# Altium schematic sheet MCU.SchDoc(MCU)
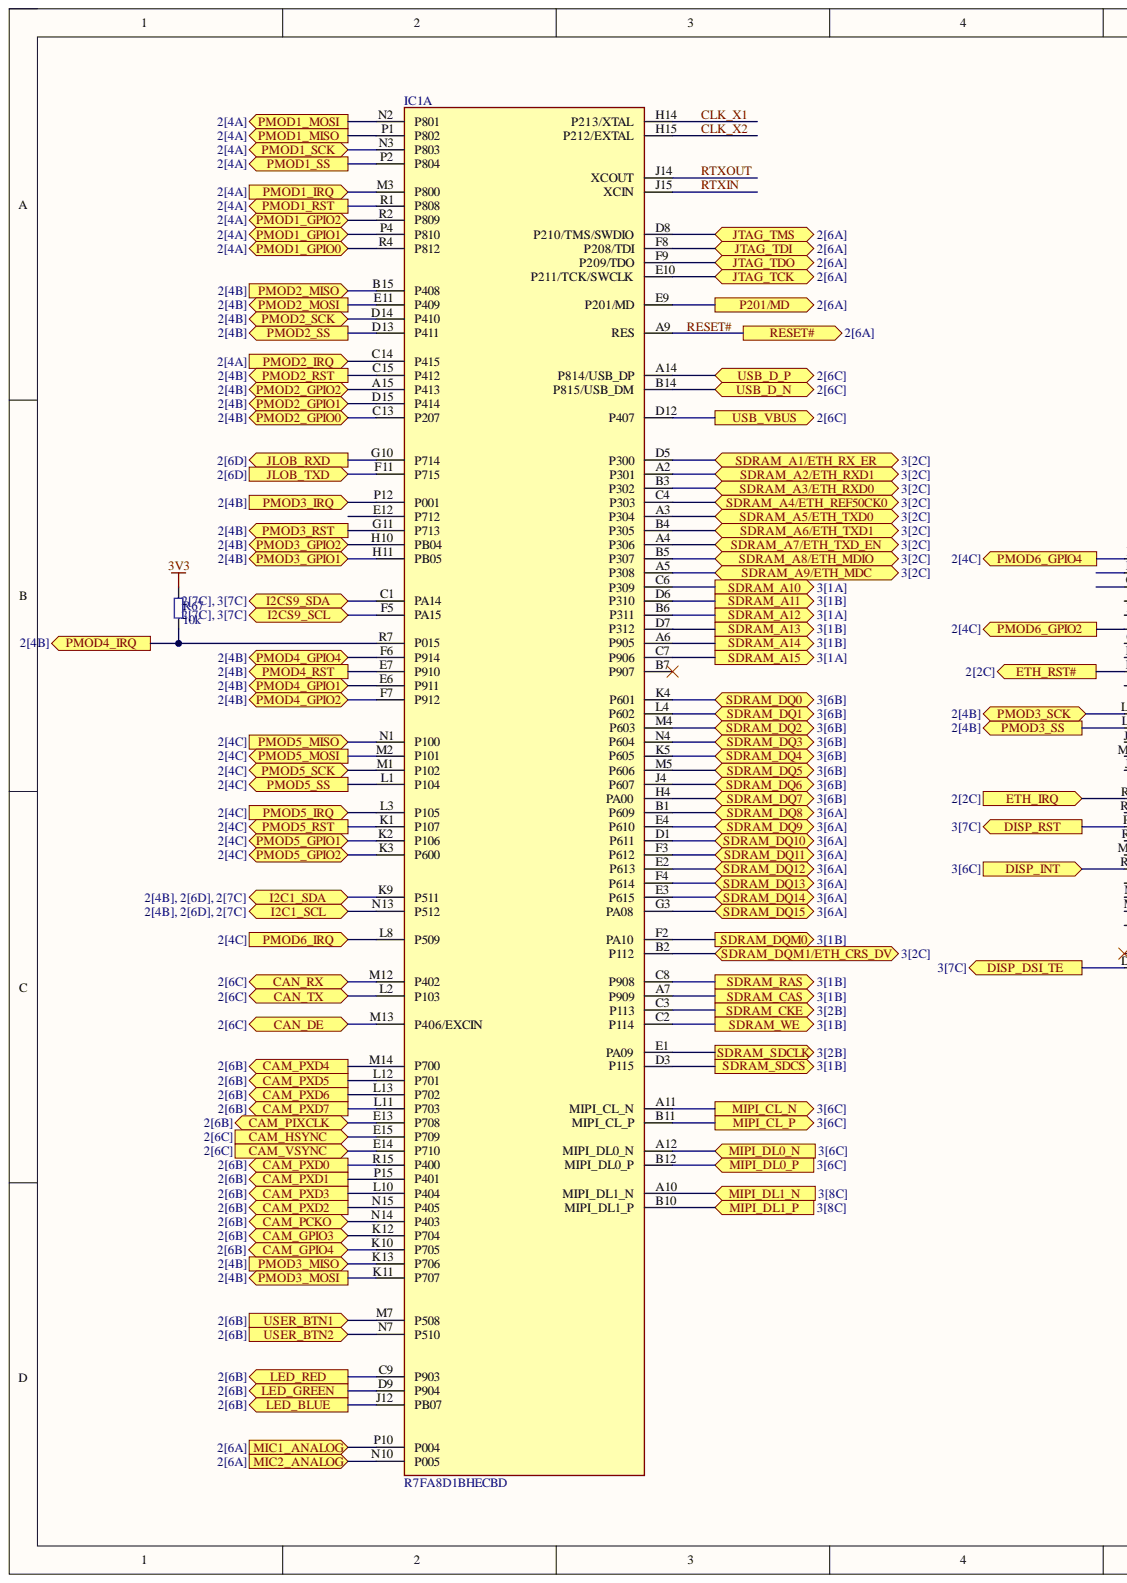
[diagram p0: MCU.SchDoc, left]
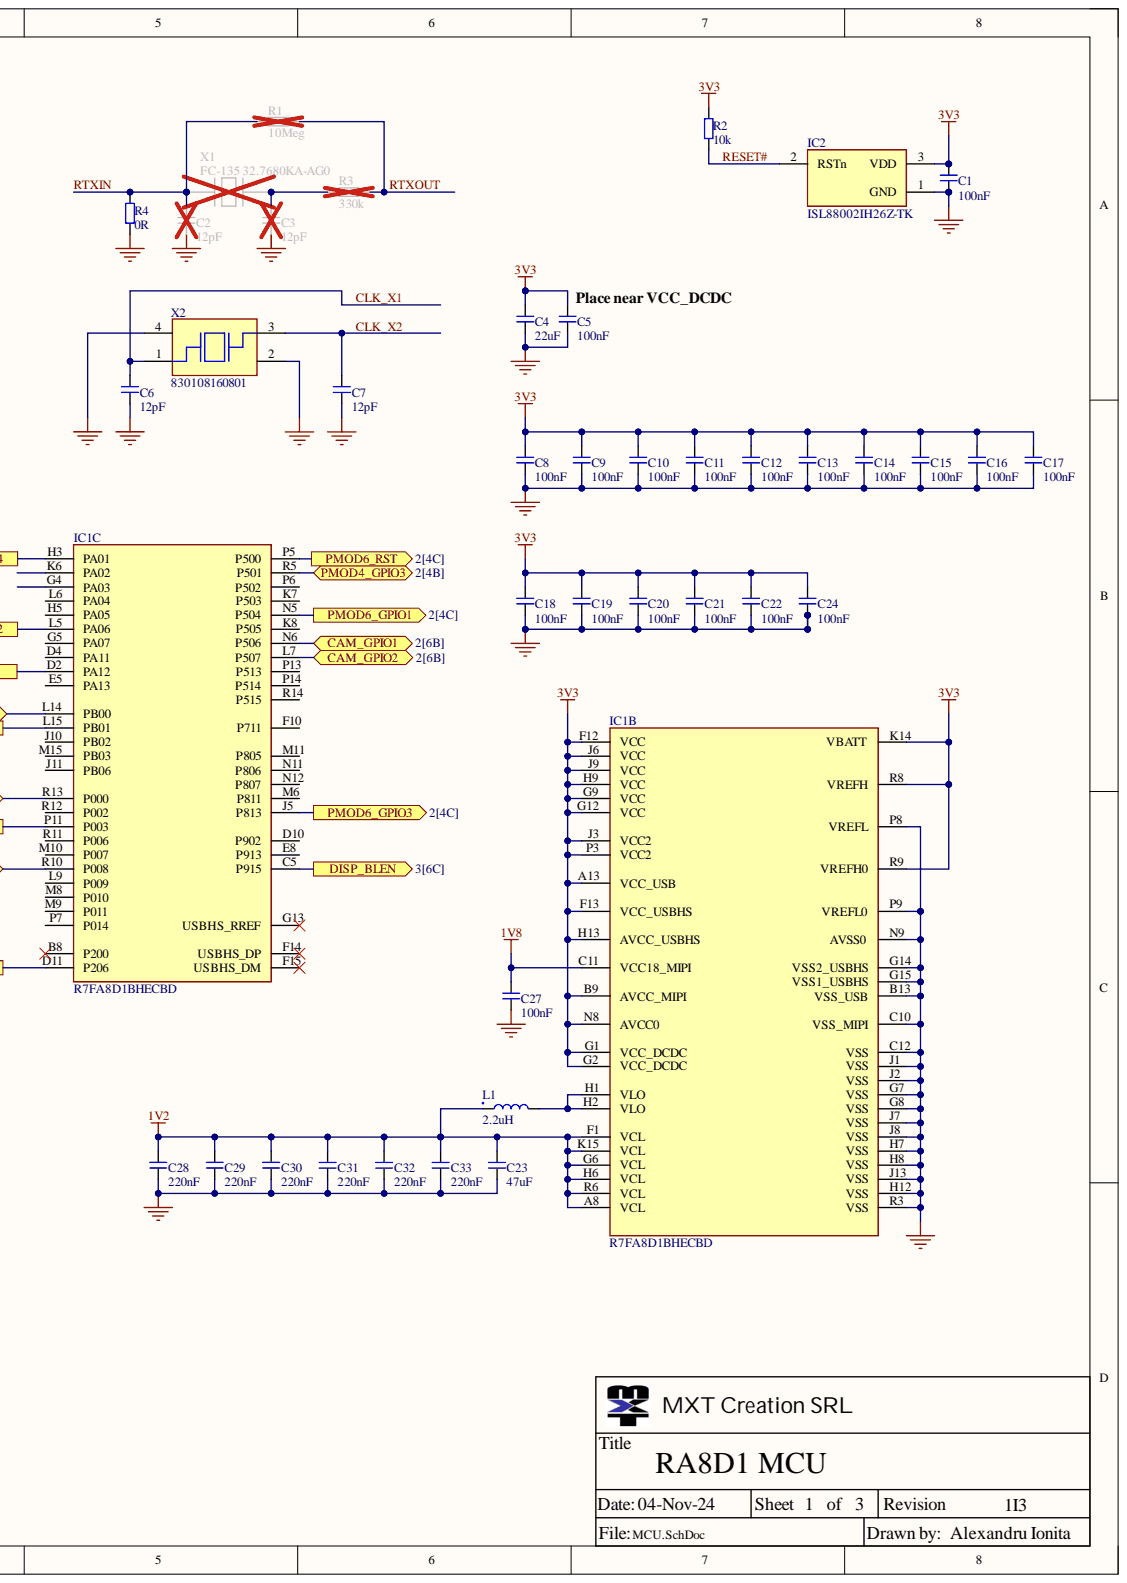
[diagram p1: MCU.SchDoc, right]

## Parts
C1  100nF  [CAP0402]
C2  12pF  [CAP0402]
C3  12pF  [CAP0402]
C4  22uF  [CAP0402]
C5  100nF  [CAP0402]
C6  12pF  [CAP0402]
C7  12pF  [CAP0402]
C8  100nF  [CAP0402]
C9  100nF  [CAP0402]
C10  100nF  [CAP0402]
C11  100nF  [CAP0402]
C12  100nF  [CAP0402]
C13  100nF  [CAP0402]
C14  100nF  [CAP0402]
C15  100nF  [CAP0402]
C16  100nF  [CAP0402]
C17  100nF  [CAP0402]
C18  100nF  [CAP0402]
C19  100nF  [CAP0402]
C20  100nF  [CAP0402]
C21  100nF  [CAP0402]
C22  100nF  [CAP0402]
C23  47uF  [CAP0603]
C24  100nF  [CAP0402]
C27  100nF  [CAP0402]
C28  220nF  [CAP0402]
C29  220nF  [CAP0402]
C30  220nF  [CAP0402]
C31  220nF  [CAP0402]
C32  220nF  [CAP0402]
C33  220nF  [CAP0402]
IC1  R7FA8D1BHECBD  [224-LFBGA-RENESAS]  (parts A,B,C)
IC2  ISL88002IH26Z-TK  [SOT-23-3-RENESAS]
L1  2.2uH  [INDUCTOR0805]
R1  10Meg  [RES0402]
R2  10k  [RES0402]
R3  330k  [RES0402]
R4  0R  [RES0402]
R67  10k  [RES0402]
X1  FC-135 32.7680KA-AG0  [3.2x1.5-EPSON]
X2  830108160801  [X1612]

## Ports
CAM_GPIO1, CAM_GPIO2, CAM_GPIO3, CAM_GPIO4, CAM_HSYNC, CAM_PCKO, CAM_PIXCLK, CAM_PXD0, CAM_PXD1, CAM_PXD2, CAM_PXD3, CAM_PXD4, CAM_PXD5, CAM_PXD6, CAM_PXD7, CAM_VSYNC, CAN_DE, CAN_RX, CAN_TX, DISP_BLEN, DISP_DSI_TE, DISP_INT, DISP_RST, ETH_IRQ, ETH_RST#, I2C1_SCL, I2C1_SDA, I2CS9_SCL, I2CS9_SDA, JLOB_RXD, JLOB_TXD, JTAG_TCK, JTAG_TDI, JTAG_TDO, JTAG_TMS, LED_BLUE, LED_GREEN, LED_RED, MIC1_ANALOG, MIC2_ANALOG, MIPI_CL_N, MIPI_CL_P, MIPI_DL0_N, MIPI_DL0_P, MIPI_DL1_N, MIPI_DL1_P, P201/MD, PMOD1_GPIO0, PMOD1_GPIO1, PMOD1_GPIO2, PMOD1_IRQ, PMOD1_MISO, PMOD1_MOSI, PMOD1_RST, PMOD1_SCK, PMOD1_SS, PMOD2_GPIO0, PMOD2_GPIO1, PMOD2_GPIO2, PMOD2_IRQ, PMOD2_MISO, PMOD2_MOSI, PMOD2_RST, PMOD2_SCK, PMOD2_SS, PMOD3_GPIO1, PMOD3_GPIO2, PMOD3_IRQ, PMOD3_MISO, PMOD3_MOSI, PMOD3_RST, PMOD3_SCK, PMOD3_SS, PMOD4_GPIO1, PMOD4_GPIO2, PMOD4_GPIO3, PMOD4_GPIO4, PMOD4_IRQ, PMOD4_RST, PMOD5_GPIO1, PMOD5_GPIO2, PMOD5_IRQ, PMOD5_MISO, PMOD5_MOSI, PMOD5_RST, PMOD5_SCK, PMOD5_SS, PMOD6_GPIO1, PMOD6_GPIO2, PMOD6_GPIO3, PMOD6_GPIO4, PMOD6_IRQ, PMOD6_RST, RESET#, SDRAM_A1/ETH_RX_ER, SDRAM_A2/ETH_RXD1, SDRAM_A3/ETH_RXD0, SDRAM_A4/ETH_REF50CK0, SDRAM_A5/ETH_TXD0, SDRAM_A6/ETH_TXD1, SDRAM_A7/ETH_TXD_EN, SDRAM_A8/ETH_MDIO, SDRAM_A9/ETH_MDC, SDRAM_A10, SDRAM_A11, SDRAM_A12, SDRAM_A13, SDRAM_A14, SDRAM_A15, SDRAM_CAS, SDRAM_CKE, SDRAM_DQ0, SDRAM_DQ1, SDRAM_DQ2, SDRAM_DQ3, SDRAM_DQ4, SDRAM_DQ5, SDRAM_DQ6, SDRAM_DQ7, SDRAM_DQ8, SDRAM_DQ9, SDRAM_DQ10, SDRAM_DQ11, SDRAM_DQ12, SDRAM_DQ13, SDRAM_DQ14, SDRAM_DQ15, SDRAM_DQM0, SDRAM_DQM1/ETH_CRS_DV, SDRAM_RAS, SDRAM_SDCLK, SDRAM_SDCS, SDRAM_WE, USB_D_N, USB_D_P, USB_VBUS, USER_BTN1, USER_BTN2

## Nets
1V2: C23.2 C28.2 C29.2 C30.2 C31.2 C32.2 C33.2 IC1.A8(VCL) IC1.F1(VCL) IC1.G6(VCL) IC1.H6(VCL) IC1.K15(VCL) IC1.R6(VCL) L1.1
1V8: C27.2 IC1.C11(VCC18_MIPI)
3V3: C1.2 C4.2 C5.2 C8.2 C9.2 C10.2 C11.2 C12.2 C13.2 C14.2 C15.2 C16.2 C17.2 C18.2 C19.2 C20.2 C21.2 C22.2 C24.2 IC1.A13(VCC_USB) IC1.B9(AVCC_MIPI) IC1.F12(VCC) IC1.F13(VCC_USBHS) IC1.G1(VCC_DCDC) IC1.G2(VCC_DCDC) IC1.G9(VCC) IC1.G12(VCC) IC1.H9(VCC) IC1.H13(AVCC_USBHS) IC1.J3(VCC2) IC1.J6(VCC) IC1.J9(VCC) IC1.K14(VBATT) IC1.N8(AVCC0) IC1.P3(VCC2) IC1.R8(VREFH) IC1.R9(VREFH0) IC2.3 R2.2 R67.2
GND: C1.1 C2.1 C3.1 C4.1 C5.1 C6.1 C7.1 C8.1 C9.1 C10.1 C11.1 C12.1 C13.1 C14.1 C15.1 C16.1 C17.1 C18.1 C19.1 C20.1 C21.1 C22.1 C23.1 C24.1 C27.1 C28.1 C29.1 C30.1 C31.1 C32.1 C33.1 IC1.B13(VSS_USB) IC1.C10(VSS_MIPI) IC1.C12(VSS) IC1.G7(VSS) IC1.G8(VSS) IC1.G14(VSS2_USBHS) IC1.G15(VSS1_USBHS) IC1.H7(VSS) IC1.H8(VSS) IC1.H12(VSS) IC1.J1(VSS) IC1.J2(VSS) IC1.J7(VSS) IC1.J8(VSS) IC1.J13(VSS) IC1.N9(AVSS0) IC1.P8(VREFL) IC1.P9(VREFL0) IC1.R3(VSS) IC2.1 R4.1 X2.2 X2.4
CAM_GPIO1: IC1.N6(P506) ; port CAM_GPIO1
CAM_GPIO2: IC1.L7(P507) ; port CAM_GPIO2
CAM_GPIO3: IC1.K12(P704) ; port CAM_GPIO3
CAM_GPIO4: IC1.K10(P705) ; port CAM_GPIO4
CAM_HSYNC: IC1.E15(P709) ; port CAM_HSYNC
CAM_PCKO: IC1.N14(P403) ; port CAM_PCKO
CAM_PIXCLK: IC1.E13(P708) ; port CAM_PIXCLK
CAM_PXD0: IC1.R15(P400) ; port CAM_PXD0
CAM_PXD1: IC1.P15(P401) ; port CAM_PXD1
CAM_PXD2: IC1.N15(P405) ; port CAM_PXD2
CAM_PXD3: IC1.L10(P404) ; port CAM_PXD3
CAM_PXD4: IC1.M14(P700) ; port CAM_PXD4
CAM_PXD5: IC1.L12(P701) ; port CAM_PXD5
CAM_PXD6: IC1.L13(P702) ; port CAM_PXD6
CAM_PXD7: IC1.L11(P703) ; port CAM_PXD7
CAM_VSYNC: IC1.E14(P710) ; port CAM_VSYNC
CAN_DE: IC1.M13(P406/EXCIN) ; port CAN_DE
CAN_RX: IC1.M12(P402) ; port CAN_RX
CAN_TX: IC1.L2(P103) ; port CAN_TX
CLK_X1: C6.2 IC1.H14(P213/XTAL) X2.1
CLK_X2: C7.2 IC1.H15(P212/EXTAL) X2.3
DISP_BLEN: IC1.C5(P915) ; port DISP_BLEN
DISP_DSI_TE: IC1.D11(P206) ; port DISP_DSI_TE
DISP_INT: IC1.R10(P008) ; port DISP_INT
DISP_RST: IC1.P11(P003) ; port DISP_RST
ETH_IRQ: IC1.R13(P000) ; port ETH_IRQ
ETH_RST#: IC1.D2(PA12) ; port ETH_RST#
I2C1_SCL: IC1.N13(P512) ; port I2C1_SCL
I2C1_SDA: IC1.K9(P511) ; port I2C1_SDA
I2CS9_SCL: IC1.F5(PA15) ; port I2CS9_SCL
I2CS9_SDA: IC1.C1(PA14) ; port I2CS9_SDA
JLOB_RXD: IC1.G10(P714) ; port JLOB_RXD
JLOB_TXD: IC1.F11(P715) ; port JLOB_TXD
JTAG_TCK: IC1.E10(P211/TCK/SWCLK) ; port JTAG_TCK
JTAG_TDI: IC1.F8(P208/TDI) ; port JTAG_TDI
JTAG_TDO: IC1.F9(P209/TDO) ; port JTAG_TDO
JTAG_TMS: IC1.D8(P210/TMS/SWDIO) ; port JTAG_TMS
LED_BLUE: IC1.J12(PB07) ; port LED_BLUE
LED_GREEN: IC1.D9(P904) ; port LED_GREEN
LED_RED: IC1.C9(P903) ; port LED_RED
MIC1_ANALOG: IC1.P10(P004) ; port MIC1_ANALOG
MIC2_ANALOG: IC1.N10(P005) ; port MIC2_ANALOG
MIPI_CL_N: IC1.A11(MIPI_CL_N) ; port MIPI_CL_N
MIPI_CL_P: IC1.B11(MIPI_CL_P) ; port MIPI_CL_P
MIPI_DL0_N: IC1.A12(MIPI_DL0_N) ; port MIPI_DL0_N
MIPI_DL0_P: IC1.B12(MIPI_DL0_P) ; port MIPI_DL0_P
MIPI_DL1_N: IC1.A10(MIPI_DL1_N) ; port MIPI_DL1_N
MIPI_DL1_P: IC1.B10(MIPI_DL1_P) ; port MIPI_DL1_P
P201/MD: IC1.E9(P201/MD) ; port P201/MD
PMOD1_GPIO0: IC1.R4(P812) ; port PMOD1_GPIO0
PMOD1_GPIO1: IC1.P4(P810) ; port PMOD1_GPIO1
PMOD1_GPIO2: IC1.R2(P809) ; port PMOD1_GPIO2
PMOD1_IRQ: IC1.M3(P800) ; port PMOD1_IRQ
PMOD1_MISO: IC1.P1(P802) ; port PMOD1_MISO
PMOD1_MOSI: IC1.N2(P801) ; port PMOD1_MOSI
PMOD1_RST: IC1.R1(P808) ; port PMOD1_RST
PMOD1_SCK: IC1.N3(P803) ; port PMOD1_SCK
PMOD1_SS: IC1.P2(P804) ; port PMOD1_SS
PMOD2_GPIO0: IC1.C13(P207) ; port PMOD2_GPIO0
PMOD2_GPIO1: IC1.D15(P414) ; port PMOD2_GPIO1
PMOD2_GPIO2: IC1.A15(P413) ; port PMOD2_GPIO2
PMOD2_IRQ: IC1.C14(P415) ; port PMOD2_IRQ
PMOD2_MISO: IC1.B15(P408) ; port PMOD2_MISO
PMOD2_MOSI: IC1.E11(P409) ; port PMOD2_MOSI
PMOD2_RST: IC1.C15(P412) ; port PMOD2_RST
PMOD2_SCK: IC1.D14(P410) ; port PMOD2_SCK
PMOD2_SS: IC1.D13(P411) ; port PMOD2_SS
PMOD3_GPIO1: IC1.H11(PB05) ; port PMOD3_GPIO1
PMOD3_GPIO2: IC1.H10(PB04) ; port PMOD3_GPIO2
PMOD3_IRQ: IC1.P12(P001) ; port PMOD3_IRQ
PMOD3_MISO: IC1.K13(P706) ; port PMOD3_MISO
PMOD3_MOSI: IC1.K11(P707) ; port PMOD3_MOSI
PMOD3_RST: IC1.G11(P713) ; port PMOD3_RST
PMOD3_SCK: IC1.L14(PB00) ; port PMOD3_SCK
PMOD3_SS: IC1.L15(PB01) ; port PMOD3_SS
PMOD4_GPIO1: IC1.E6(P911) ; port PMOD4_GPIO1
PMOD4_GPIO2: IC1.F7(P912) ; port PMOD4_GPIO2
PMOD4_GPIO3: IC1.R5(P501) ; port PMOD4_GPIO3
PMOD4_GPIO4: IC1.F6(P914) ; port PMOD4_GPIO4
PMOD4_IRQ: IC1.R7(P015) R67.1 ; port PMOD4_IRQ
PMOD4_RST: IC1.E7(P910) ; port PMOD4_RST
PMOD5_GPIO1: IC1.K2(P106) ; port PMOD5_GPIO1
PMOD5_GPIO2: IC1.K3(P600) ; port PMOD5_GPIO2
PMOD5_IRQ: IC1.L3(P105) ; port PMOD5_IRQ
PMOD5_MISO: IC1.N1(P100) ; port PMOD5_MISO
PMOD5_MOSI: IC1.M2(P101) ; port PMOD5_MOSI
PMOD5_RST: IC1.K1(P107) ; port PMOD5_RST
PMOD5_SCK: IC1.M1(P102) ; port PMOD5_SCK
PMOD5_SS: IC1.L1(P104) ; port PMOD5_SS
PMOD6_GPIO1: IC1.N5(P504) ; port PMOD6_GPIO1
PMOD6_GPIO2: IC1.L5(PA06) ; port PMOD6_GPIO2
PMOD6_GPIO3: IC1.J5(P813) ; port PMOD6_GPIO3
PMOD6_GPIO4: IC1.H3(PA01) ; port PMOD6_GPIO4
PMOD6_IRQ: IC1.L8(P509) ; port PMOD6_IRQ
PMOD6_RST: IC1.P5(P500) ; port PMOD6_RST
RESET#: IC1.A9(RES) IC2.2 R2.1 ; port RESET#
RTXIN: C2.2 IC1.J15(XCIN) R1.1 R4.2 X1.1
RTXOUT: IC1.J14(XCOUT) R1.2 R3.2
SDRAM_A1/ETH_RX_ER: IC1.D5(P300) ; port SDRAM_A1/ETH_RX_ER
SDRAM_A2/ETH_RXD1: IC1.A2(P301) ; port SDRAM_A2/ETH_RXD1
SDRAM_A3/ETH_RXD0: IC1.B3(P302) ; port SDRAM_A3/ETH_RXD0
SDRAM_A4/ETH_REF50CK0: IC1.C4(P303) ; port SDRAM_A4/ETH_REF50CK0
SDRAM_A5/ETH_TXD0: IC1.A3(P304) ; port SDRAM_A5/ETH_TXD0
SDRAM_A6/ETH_TXD1: IC1.B4(P305) ; port SDRAM_A6/ETH_TXD1
SDRAM_A7/ETH_TXD_EN: IC1.A4(P306) ; port SDRAM_A7/ETH_TXD_EN
SDRAM_A8/ETH_MDIO: IC1.B5(P307) ; port SDRAM_A8/ETH_MDIO
SDRAM_A9/ETH_MDC: IC1.A5(P308) ; port SDRAM_A9/ETH_MDC
SDRAM_A10: IC1.C6(P309) ; port SDRAM_A10
SDRAM_A11: IC1.D6(P310) ; port SDRAM_A11
SDRAM_A12: IC1.B6(P311) ; port SDRAM_A12
SDRAM_A13: IC1.D7(P312) ; port SDRAM_A13
SDRAM_A14: IC1.A6(P905) ; port SDRAM_A14
SDRAM_A15: IC1.C7(P906) ; port SDRAM_A15
SDRAM_CAS: IC1.A7(P909) ; port SDRAM_CAS
SDRAM_CKE: IC1.C3(P113) ; port SDRAM_CKE
SDRAM_DQ0: IC1.K4(P601) ; port SDRAM_DQ0
SDRAM_DQ1: IC1.L4(P602) ; port SDRAM_DQ1
SDRAM_DQ2: IC1.M4(P603) ; port SDRAM_DQ2
SDRAM_DQ3: IC1.N4(P604) ; port SDRAM_DQ3
SDRAM_DQ4: IC1.K5(P605) ; port SDRAM_DQ4
SDRAM_DQ5: IC1.M5(P606) ; port SDRAM_DQ5
SDRAM_DQ6: IC1.J4(P607) ; port SDRAM_DQ6
SDRAM_DQ7: IC1.H4(PA00) ; port SDRAM_DQ7
SDRAM_DQ8: IC1.B1(P609) ; port SDRAM_DQ8
SDRAM_DQ9: IC1.E4(P610) ; port SDRAM_DQ9
SDRAM_DQ10: IC1.D1(P611) ; port SDRAM_DQ10
SDRAM_DQ11: IC1.F3(P612) ; port SDRAM_DQ11
SDRAM_DQ12: IC1.E2(P613) ; port SDRAM_DQ12
SDRAM_DQ13: IC1.F4(P614) ; port SDRAM_DQ13
SDRAM_DQ14: IC1.E3(P615) ; port SDRAM_DQ14
SDRAM_DQ15: IC1.G3(PA08) ; port SDRAM_DQ15
SDRAM_DQM0: IC1.F2(PA10) ; port SDRAM_DQM0
SDRAM_DQM1/ETH_CRS_DV: IC1.B2(P112) ; port SDRAM_DQM1/ETH_CRS_DV
SDRAM_RAS: IC1.C8(P908) ; port SDRAM_RAS
SDRAM_SDCLK: IC1.E1(PA09) ; port SDRAM_SDCLK
SDRAM_SDCS: IC1.D3(P115) ; port SDRAM_SDCS
SDRAM_WE: IC1.C2(P114) ; port SDRAM_WE
USB_D_N: IC1.B14(P815/USB_DM) ; port USB_D_N
USB_D_P: IC1.A14(P814/USB_DP) ; port USB_D_P
USB_VBUS: IC1.D12(P407) ; port USB_VBUS
USER_BTN1: IC1.M7(P508) ; port USER_BTN1
USER_BTN2: IC1.N7(P510) ; port USER_BTN2
NetC3_2: C3.2 R3.1 X1.2
NetIC1_H1: IC1.H1(VLO) IC1.H2(VLO) L1.2

Not connected: IC1 B7(P907) B8(P200) D4(PA11) D10(P902) E5(PA13) E8(P913) E12(P712) F10(P711) F14(USBHS_DP) F15(USBHS_DM) G4(PA03) G5(PA07) G13(USBHS_RREF) H5(PA05) J10(PB02) J11(PB06) K6(PA02) K7(P503) K8(P505) L6(PA04) L9(P009) M6(P811) M8(P010) M9(P011) M10(P007) M11(P805) M15(PB03) N11(P806) N12(P807) P6(P502) P7(P014) P13(P513) P14(P514) R11(P006) R12(P002) R14(P515)

## Library data of the parts
C1 C5 C8-C22 C24 C27 = GRM155R71E104KE14J: CAP CER 0.1UF 10% 25V X7R 0402; Manufacturer: Murata; .Checked_PCB: AI; Component Kind: Standard; Manufacturer Part Number: GRM155R71E104KE14J; .Checked_SCH: AI; .Creator: AI; Library Name: MXT_Library.IntLib
C2 C3 C6 C7 = GJM1555C1H120GB01D: CAP CER 12PF 50V C0G/NP0 0402; Manufacturer: Murata; Component Kind: Standard; Manufacturer Part Number: GJM1555C1H120GB01D; Library Name: MXT_Library.IntLib
C4 = GRM158R60J226ME01D: CAP CER 22UF 6.3V X5R 0402; Manufacturer: Murata; .Creator: Ionut Chirilus; Component Kind: Standard; Manufacturer Part Number: GRM158R60J226ME01D; Library Name: MXT_Library.IntLib
C23 = GRM188R60J476ME15J: CAP CER 47uF 20% 6.3V X5R 0603; Manufacturer: Murata; .Checked_PCB: AI; Component Kind: Standard; Manufacturer Part Number: GRM188R60J476ME15J; .Checked_SCH: AI; .Creator: AI; Library Name: MXT_Library.IntLib
C28-C33 = GCM155R71C224KE02J: CAP CER 0.22UF 16V X7R 0402; Manufacturer: Murata; Component Kind: Standard; Manufacturer Part Number: GCM155R71C224KE02J; Library Name: MXT_Library.IntLib
IC1 = R7FA8D1BHECBD: High-performance 480 MHz Arm® Cortex®-M85 core, 32-Bit MCU  Renesas RA8 Series; .Creator: AI; Component Kind: Standard; Manufacturer: Renesas; Manufacturer Part Number: R7FA8D1BHECBD; Library Name: MXT_Library.IntLib
IC2 = ISL88002IH26Z-TK: 2.63V Volatage Supervisory circuit; Manufacturer: Renesas; .Checked_PCB: LV; .Creator: AI; Component Kind: Standard; Manufacturer Part Number: ISL88002IH26Z-TK; .Checked_SCH: LV; Library Name: MXT_Library.IntLib
L1 = LQM21PN2R2NGCD: Power Inductors - SMD 2.2 UH 30%; Manufacturer: Murata; .Creator: AI; Component Kind: Standard; Manufacturer Part Number: LQM21PN2R2NGCD; Library Name: MXT_Library.IntLib
R1 = RC0402FR-0710ML: RES SMD 10Meg OHM 1% 1/16W 0402; Manufacturer: Yageo; Component Kind: Standard; Manufacturer Part Number: RC0402FR-0710ML; Library Name: MXT_Library.IntLib
R2 R67 = RC0402FR-0710KL: RES SMD 10K OHM 1% 1/16W 0402; Manufacturer: Yageo; .Checked_PCB: AI; Component Kind: Standard; Manufacturer Part Number: RC0402FR-0710KL; .Checked_SCH: AI; .Creator: AI; Library Name: MXT_Library.IntLib
R3 = RC0402FR-07330KL: RES SMD 330k OHM 1% 1/16W 0402; Manufacturer: Yageo; Component Kind: Standard; Manufacturer Part Number: RC0402FR-07330KL; Library Name: MXT_Library.IntLib
R4 = RC0402FR-070RL: RES SMD 0 OHM JUMPER 1/16W 0402; Manufacturer: Yageo; .Checked_PCB: AI; Component Kind: Standard; Manufacturer Part Number: RC0402FR-070RL; .Checked_SCH: AI; .Creator: AI; Library Name: MXT_Library.IntLib
X1 = FC-135 32.7680KA-AG0: Crystals 32.768KHz 20ppm 7.0pF -40C +85C; Manufacturer: Epson; .Checked_PCB: LV; .Creator: IC; Component Kind: Standard; Manufacturer Part Number: FC-135 32.7680KA-AG0; .Checked_SCH: LV; Library Name: MXT_Library.IntLib
X2 = 830108160801: Crystals WE-XTAL 24.0MHz 20ppm 8pF 1.6 x 1.2mm; Manufacturer: Wurth Elektronik; .Checked_PCB: LV; .Creator: AI; Component Kind: Standard; Manufacturer Part Number: 830108160801; .Checked_SCH: LV; Library Name: MXT_Library.IntLib
